# Altium schematic sheet TUN7_Control.SchDoc(TUN7_Control)
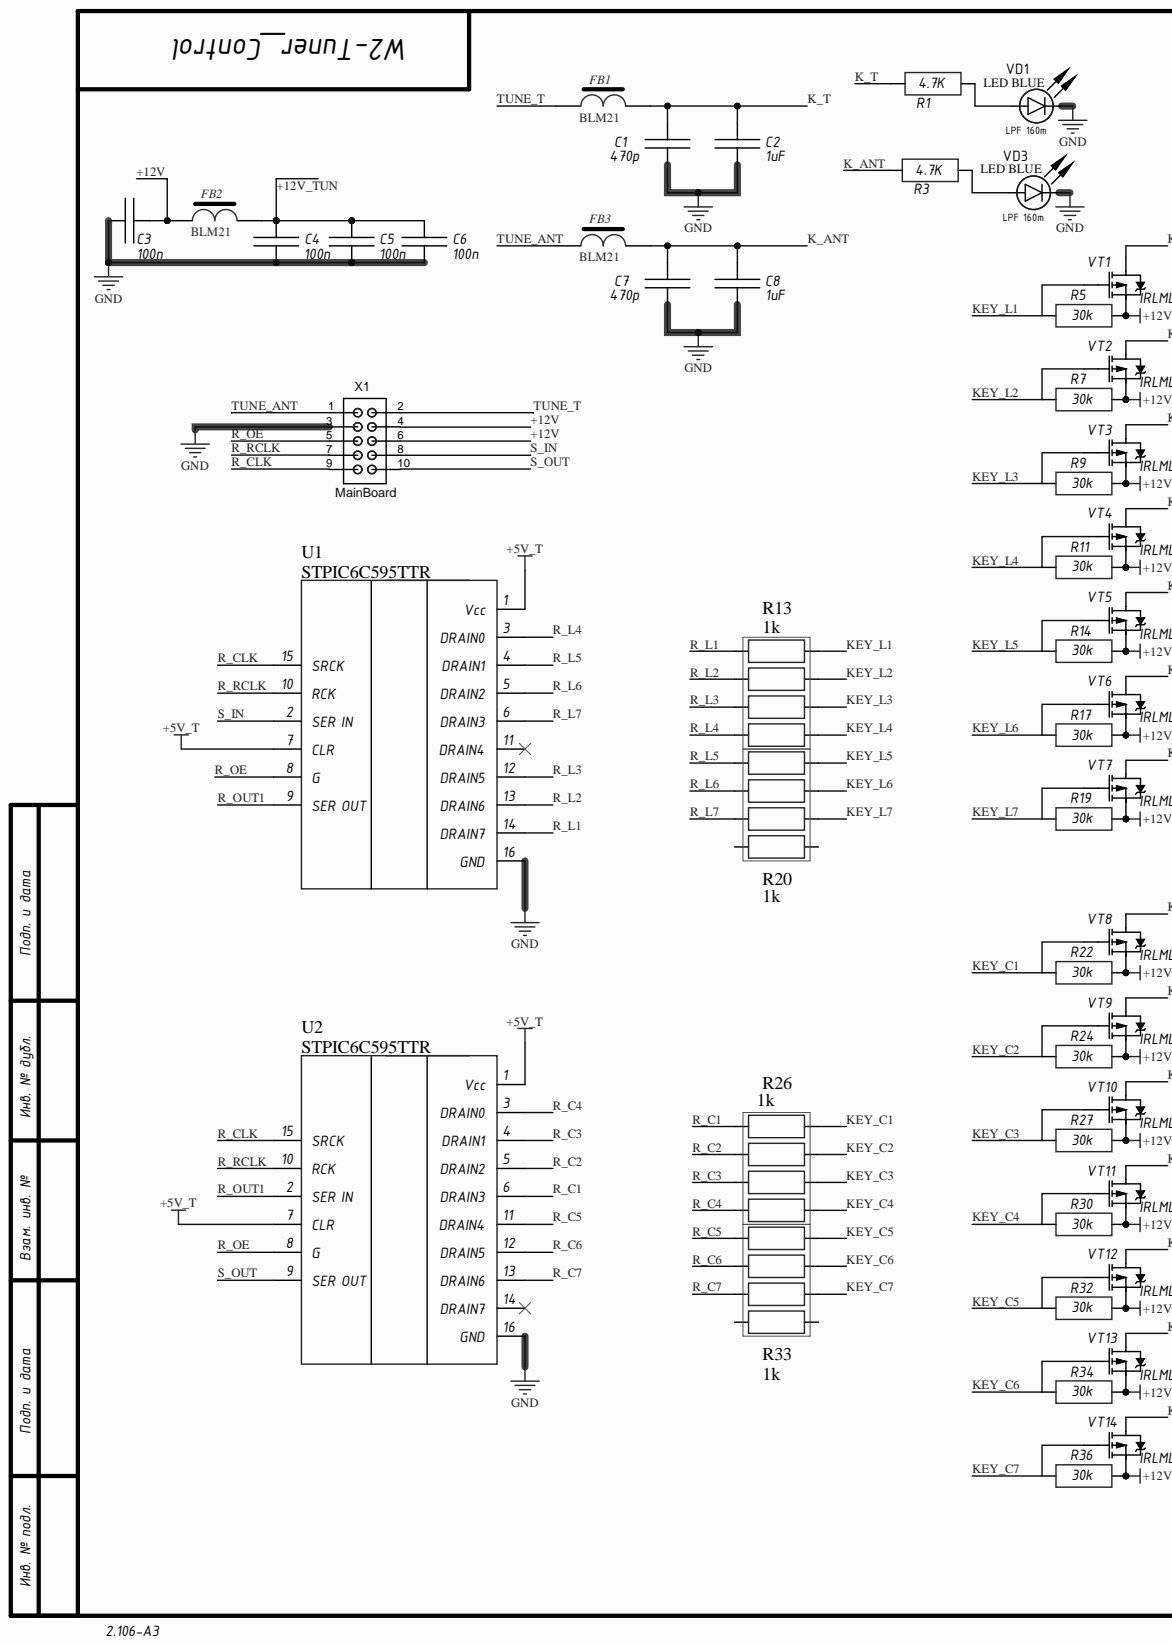
[diagram p0: TUN7_Control.SchDoc, left]
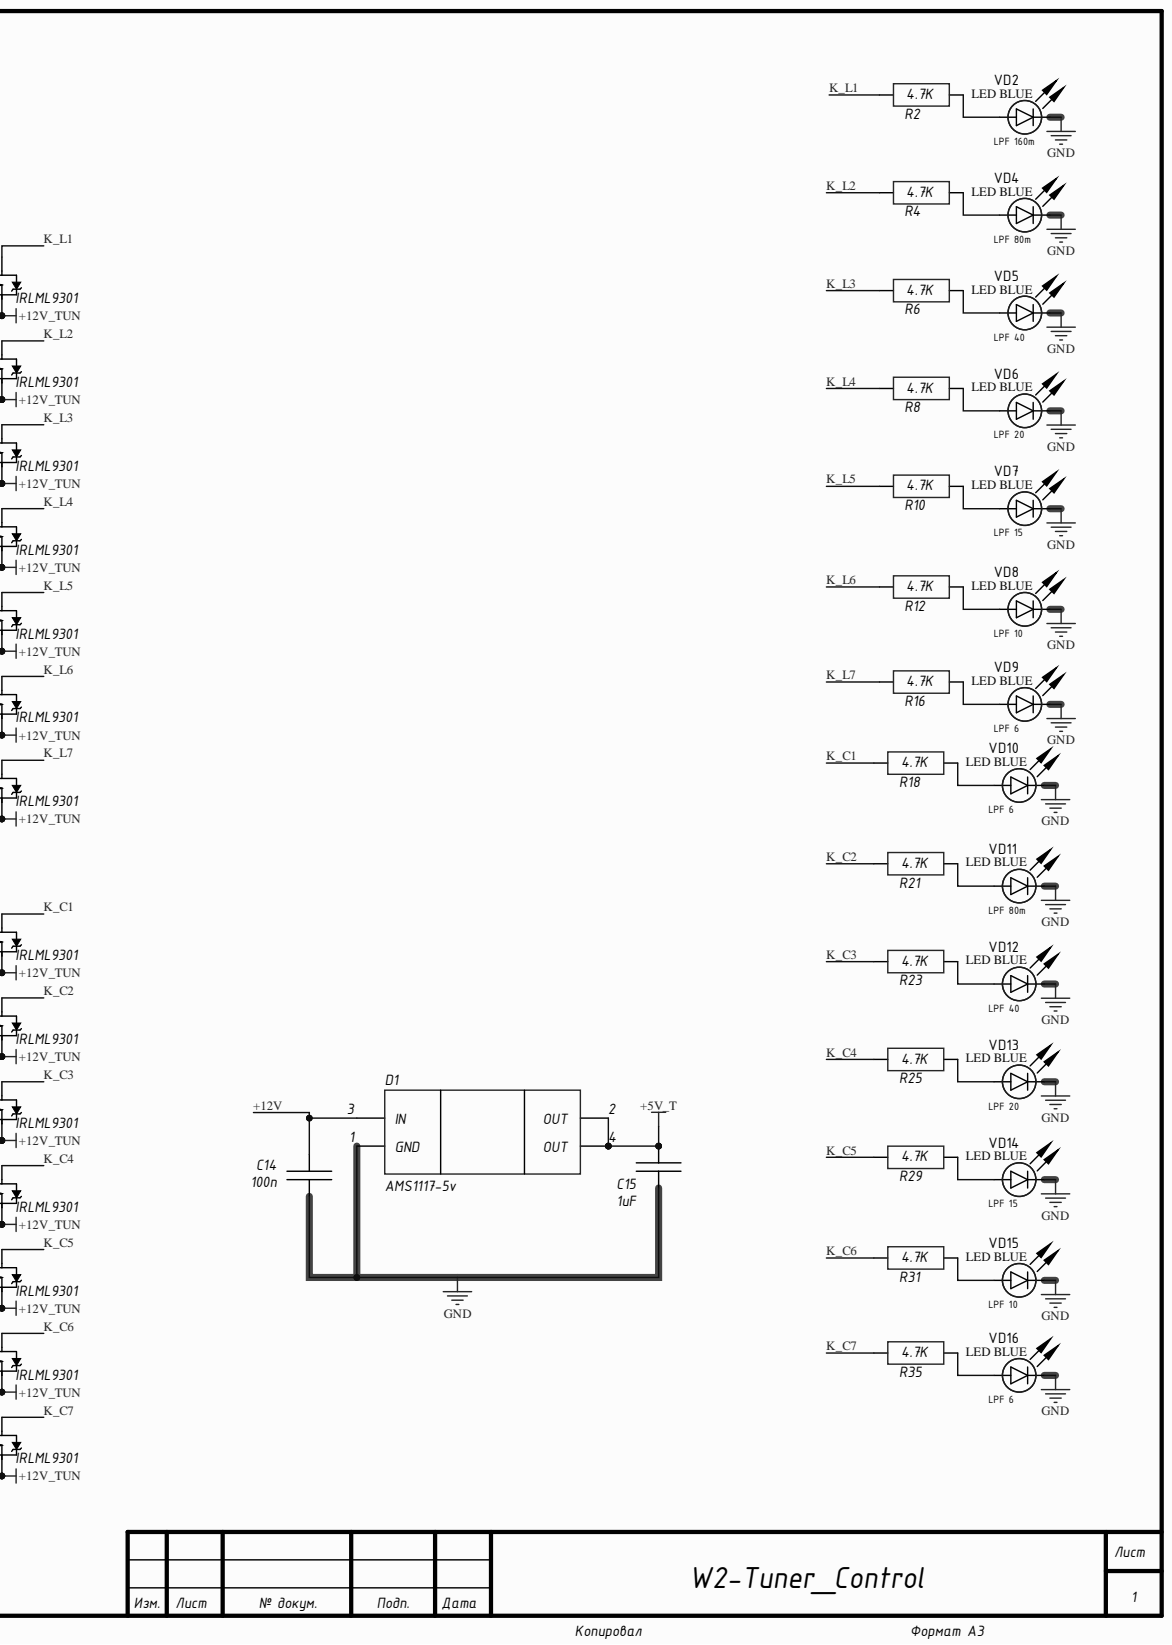
[diagram p1: TUN7_Control.SchDoc, right]

## Parts
C1  470p  [CH0805C]
C2  1uF  [CH0805C]
C3  100n  [CH0805C]
C4  100n  [CH0805C]
C5  100n  [CH0805C]
C6  100n  [CH0805C]
C7  470p  [CH0805C]
C8  1uF  [CH0805C]
C14  100n  [CH0805C]
C15  1uF  [CH0805C]
D1  AMS1117-5v  [SOT223]
FB1  BLM21  [CH0805L]
FB2  BLM21  [CH0805L]
FB3  BLM21  [CH0805L]
R1  4.7K  [CH0805R]
R2  4.7K  [CH0805R]
R3  4.7K  [CH0805R]
R4  4.7K  [CH0805R]
R5  30k  [CH0805R]
R6  4.7K  [CH0805R]
R7  30k  [CH0805R]
R8  4.7K  [CH0805R]
R9  30k  [CH0805R]
R10  4.7K  [CH0805R]
R11  30k  [CH0805R]
R12  4.7K  [CH0805R]
R13  1k  [CAT16]
R14  30k  [CH0805R]
R16  4.7K  [CH0805R]
R17  30k  [CH0805R]
R18  4.7K  [CH0805R]
R19  30k  [CH0805R]
R20  1k  [CAT16]
R21  4.7K  [CH0805R]
R22  30k  [CH0805R]
R23  4.7K  [CH0805R]
R24  30k  [CH0805R]
R25  4.7K  [CH0805R]
R26  1k  [CAT16]
R27  30k  [CH0805R]
R29  4.7K  [CH0805R]
R30  30k  [CH0805R]
R31  4.7K  [CH0805R]
R32  30k  [CH0805R]
R33  1k  [CAT16]
R34  30k  [CH0805R]
R35  4.7K  [CH0805R]
R36  30k  [CH0805R]
U1  STPIC6C595TTR  [16-TSOP]
U2  STPIC6C595TTR  [16-TSOP]
VD1  LED BLUE  Color: LPF 160m  TypeDiode: LED  [LED: 0805B]
VD2  LED BLUE  Color: LPF 160m  TypeDiode: LED  [LED: 0805B]
VD3  LED BLUE  Color: LPF 160m  TypeDiode: LED  [LED: 0805B]
VD4  LED BLUE  Color: LPF 80m  TypeDiode: LED  [LED: 0805B]
VD5  LED BLUE  Color: LPF 40  TypeDiode: LED  [LED: 0805B]
VD6  LED BLUE  Color: LPF 20  TypeDiode: LED  [LED: 0805B]
VD7  LED BLUE  Color: LPF 15  TypeDiode: LED  [LED: 0805B]
VD8  LED BLUE  Color: LPF 10  TypeDiode: LED  [LED: 0805B]
VD9  LED BLUE  Color: LPF 6  TypeDiode: LED  [LED: 0805B]
VD10  LED BLUE  Color: LPF 6  TypeDiode: LED  [LED: 0805B]
VD11  LED BLUE  Color: LPF 80m  TypeDiode: LED  [LED: 0805B]
VD12  LED BLUE  Color: LPF 40  TypeDiode: LED  [LED: 0805B]
VD13  LED BLUE  Color: LPF 20  TypeDiode: LED  [LED: 0805B]
VD14  LED BLUE  Color: LPF 15  TypeDiode: LED  [LED: 0805B]
VD15  LED BLUE  Color: LPF 10  TypeDiode: LED  [LED: 0805B]
VD16  LED BLUE  Color: LPF 6  TypeDiode: LED  [LED: 0805B]
VT1  IRLML9301  [SOT23_132]
VT2  IRLML9301  [SOT23_132]
VT3  IRLML9301  [SOT23_132]
VT4  IRLML9301  [SOT23_132]
VT5  IRLML9301  [SOT23_132]
VT6  IRLML9301  [SOT23_132]
VT7  IRLML9301  [SOT23_132]
VT8  IRLML9301  [SOT23_132]
VT9  IRLML9301  [SOT23_132]
VT10  IRLML9301  [SOT23_132]
VT11  IRLML9301  [SOT23_132]
VT12  IRLML9301  [SOT23_132]
VT13  IRLML9301  [SOT23_132]
VT14  IRLML9301  [SOT23_132]
X1  MainBoard  [CON: BH-10]

## Nets
+5V_T: C15.2 D1.2(OUT) D1.4(OUT) U1.1(Vcc) U1.7(CLR) U2.1(Vcc) U2.7(CLR)
GND: C1.2 C2.2 C3.1 C4.1 C5.1 C6.1 C7.2 C8.2 C14.1 C15.1 D1.1(GND) U1.16(GND) U2.16(GND) VD1.2 VD2.2 VD3.2 VD4.2 VD5.2 VD6.2 VD7.2 VD8.2 VD9.2 VD10.2 VD11.2 VD12.2 VD13.2 VD14.2 VD15.2 VD16.2 X1.3
+12V: C3.2 C14.2 D1.3(IN) FB2.2 X1.4 X1.6
+12V_TUN: C4.2 C5.2 C6.2 FB2.1 R5.1 R7.1 R9.1 R11.1 R14.1 R17.1 R19.1 R22.1 R24.1 R27.1 R30.1 R32.1 R34.1 R36.1 VT1.2 VT2.2 VT3.2 VT4.2 VT5.2 VT6.2 VT7.2 VT8.2 VT9.2 VT10.2 VT11.2 VT12.2 VT13.2 VT14.2
K_ANT: C7.1 C8.1 FB3.1 R3.2
K_C1: R18.2 VT8.3
K_C2: R21.2 VT9.3
K_C3: R23.2 VT10.3
K_C4: R25.2 VT11.3
K_C5: R29.2 VT12.3
K_C6: R31.2 VT13.3
K_C7: R35.2 VT14.3
K_L1: R2.2 VT1.3
K_L2: R4.2 VT2.3
K_L3: R6.2 VT3.3
K_L4: R8.2 VT4.3
K_L5: R10.2 VT5.3
K_L6: R12.2 VT6.3
K_L7: R16.2 VT7.3
K_T: C1.1 C2.1 FB1.1 R1.2
KEY_C1: R22.2 R26.5 VT8.1
KEY_C2: R24.2 R26.6 VT9.1
KEY_C3: R26.7 R27.2 VT10.1
KEY_C4: R26.8 R30.2 VT11.1
KEY_C5: R32.2 R33.5 VT12.1
KEY_C6: R33.6 R34.2 VT13.1
KEY_C7: R33.7 R36.2 VT14.1
KEY_L1: R5.2 R13.5 VT1.1
KEY_L2: R7.2 R13.6 VT2.1
KEY_L3: R9.2 R13.7 VT3.1
KEY_L4: R11.2 R13.8 VT4.1
KEY_L5: R14.2 R20.5 VT5.1
KEY_L6: R17.2 R20.6 VT6.1
KEY_L7: R19.2 R20.7 VT7.1
R_C1: R26.1 U2.6(DRAIN3)
R_C2: R26.2 U2.5(DRAIN2)
R_C3: R26.3 U2.4(DRAIN1)
R_C4: R26.4 U2.3(DRAIN0)
R_C5: R33.1 U2.11(DRAIN4)
R_C6: R33.2 U2.12(DRAIN5)
R_C7: R33.3 U2.13(DRAIN6)
R_CLK: U1.15(SRCK) U2.15(SRCK) X1.9
R_L1: R13.1 U1.14(DRAIN7)
R_L2: R13.2 U1.13(DRAIN6)
R_L3: R13.3 U1.12(DRAIN5)
R_L4: R13.4 U1.3(DRAIN0)
R_L5: R20.1 U1.4(DRAIN1)
R_L6: R20.2 U1.5(DRAIN2)
R_L7: R20.3 U1.6(DRAIN3)
R_OE: U1.8(G) U2.8(G) X1.5
R_OUT1: U1.9(SER) U2.2(SER)
R_RCLK: U1.10(RCK) U2.10(RCK) X1.7
S_IN: U1.2(SER) X1.8
S_OUT: U2.9(SER) X1.10
TUNE_ANT: FB3.2 X1.1
TUNE_T: FB1.2 X1.2
NetR1_1: R1.1 VD1.1
NetR2_1: R2.1 VD2.1
NetR3_1: R3.1 VD3.1
NetR4_1: R4.1 VD4.1
NetR6_1: R6.1 VD5.1
NetR8_1: R8.1 VD6.1
NetR10_1: R10.1 VD7.1
NetR12_1: R12.1 VD8.1
NetR16_1: R16.1 VD9.1
NetR18_1: R18.1 VD10.1
NetR21_1: R21.1 VD11.1
NetR23_1: R23.1 VD12.1
NetR25_1: R25.1 VD13.1
NetR29_1: R29.1 VD14.1
NetR31_1: R31.1 VD15.1
NetR35_1: R35.1 VD16.1

Not connected: R20 4 8; R33 4 8; U1 11(DRAIN4); U2 14(DRAIN7)

## Library data of the parts
C1-C8 C14 C15 = Capacitor: Component Kind: Standard; Library Name: Discrete.SchLib
D1 = NCP1117LPST50T3G: LDO; Manufacturer: ON Semiconductor; Component Kind: Standard; ComponentKind: SOT-223; HelpURL: https://www.digikey.com/product-detail/en/on-semiconductor/NCP1117LPST50T3G/NCP1117LPST50T3GOSCT-ND/5801728; Library Name: Power Supplies.SchLib; Model: NCP1117LPST50T3G
FB1-FB3 = FB-1: Component Kind: Standard; Library Name: Discrete.SchLib
R1-R12 R14 R16-R19 R21-R25 R27 R29-R32 R34-R36 = Resistor: Component Kind: Standard; Library Name: Discrete.SchLib
R13 R20 R26 R33 = CAT10-0804: Component Kind: Standard; Library Name: Discrete.SchLib
VD1-VD16 = LED_single: ValueManufacturerPartNumber: LED, *, *, *, -55...+150°C; Component Kind: Standard; Library Name: Diode.SchLib; Temperature: -55...+150°C
VT1-VT14 = IRLML9301: Mosfet; Component Kind: Standard; Library Name: Transistor.SchLib
X1 = IDC10: 2*5 Pin; Component Kind: Standard
U1 U2 = STPIC6C595TTR: Component Kind: Standard; Library Name: Digital.SchLib
